# Altium schematic sheet LIDO-HW.100.RearConnector.SchDoc(LIDO-HW.100.RearConnector)
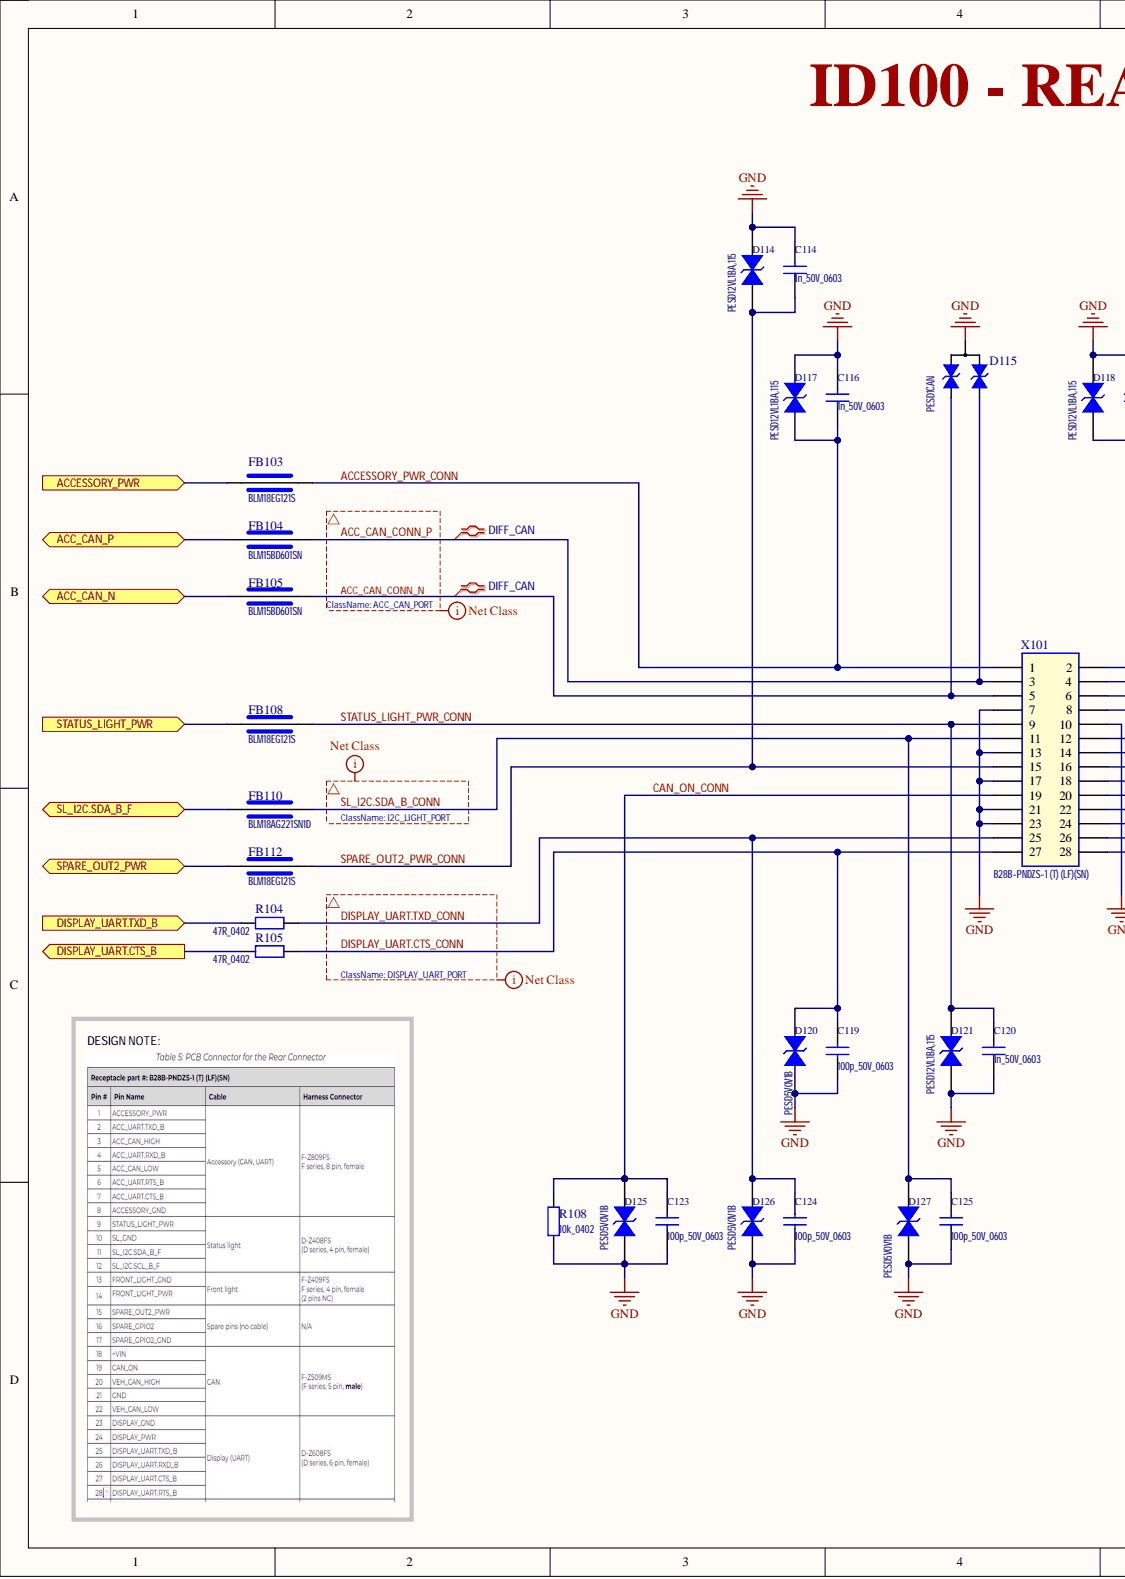
[diagram p0: LIDO-HW.100.RearConnector.SchDoc, left]
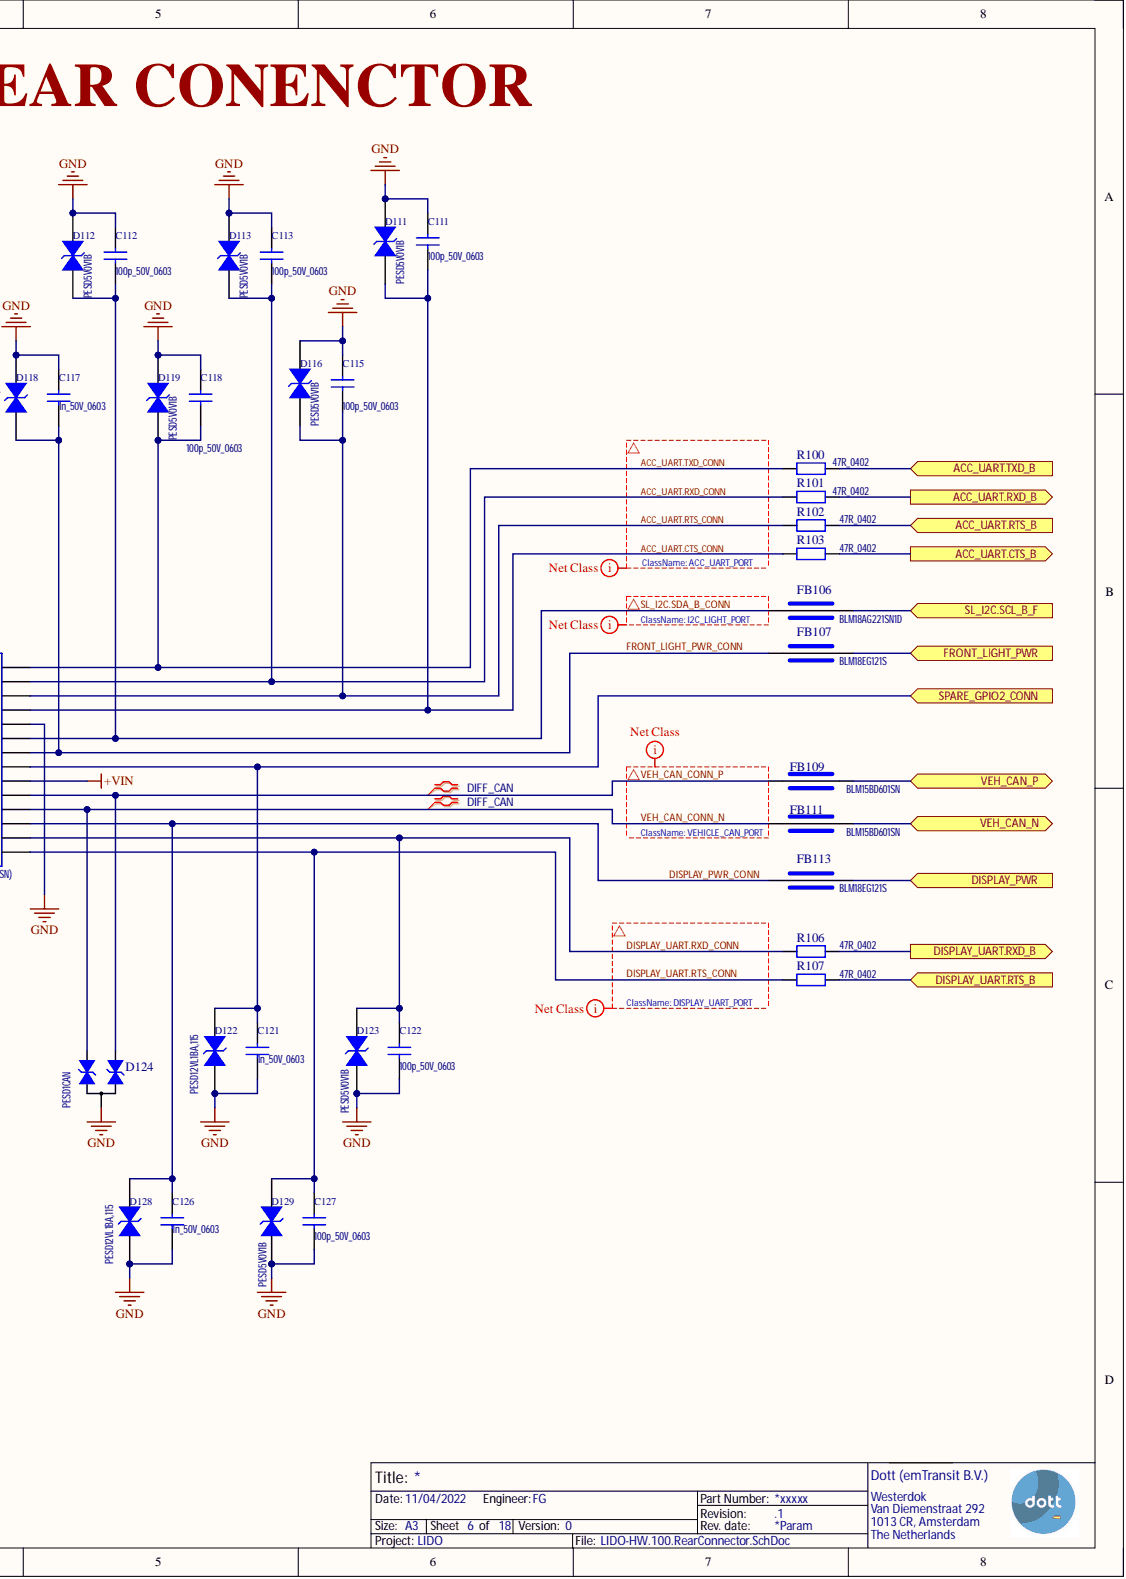
[diagram p1: LIDO-HW.100.RearConnector.SchDoc, right]

## Parts
C111  100p_50V_0603
C112  100p_50V_0603
C113  100p_50V_0603
C114  1n_50V_0603
C115  100p_50V_0603
C116  1n_50V_0603
C117  1n_50V_0603
C118  100p_50V_0603
C119  100p_50V_0603
C120  1n_50V_0603
C121  1n_50V_0603
C122  100p_50V_0603
C123  100p_50V_0603
C124  100p_50V_0603
C125  100p_50V_0603
C126  1n_50V_0603
C127  100p_50V_0603
D111  PESD5V0V1B
D112  PESD5V0V1B
D113  PESD5V0V1B
D114  PESD12VL1BA,115
D115  PESD1CAN
D116  PESD5V0V1B
D117  PESD12VL1BA,115
D118  PESD12VL1BA,115
D119  PESD5V0V1B
D120  PESD5V0V1B
D121  PESD12VL1BA,115
D122  PESD12VL1BA,115
D123  PESD5V0V1B
D124  PESD1CAN
D125  PESD5V0V1B
D126  PESD5V0V1B
D127  PESD5V0V1B
D128  PESD12VL1BA,115
D129  PESD5V0V1B
FB103  BLM18EG121S
FB104  BLM15BD601SN
FB105  BLM15BD601SN
FB106  BLM18AG221SN1D
FB107  BLM18EG121S
FB108  BLM18EG121S
FB109  BLM15BD601SN
FB110  BLM18AG221SN1D
FB111  BLM15BD601SN
FB112  BLM18EG121S
FB113  BLM18EG121S
R100  47R_0402
R101  47R_0402
R102  47R_0402
R103  47R_0402
R104  47R_0402
R105  47R_0402
R106  47R_0402
R107  47R_0402
R108  10k_0402
X101  B28B-PNDZS-1 (T) (LF)(SN)

## Ports
ACC_CAN_N, ACC_CAN_P, ACC_UART.CTS_B, ACC_UART.RTS_B, ACC_UART.RXD_B, ACC_UART.TXD_B, ACCESSORY_PWR, DISPLAY_PWR, DISPLAY_UART.CTS_B, DISPLAY_UART.RTS_B, DISPLAY_UART.RXD_B, DISPLAY_UART.TXD_B, FRONT_LIGHT_PWR, SL_I2C.SCL_B_F, SL_I2C.SDA_B_F, SPARE_GPIO2_CONN, SPARE_OUT2_PWR, STATUS_LIGHT_PWR, VEH_CAN_N, VEH_CAN_P

## Nets
+VIN: X101.18
GND: C111.1 C112.1 C113.1 C114.2 C115.1 C116.2 C117.2 C118.1 C119.1 C120.1 C121.1 C122.1 C123.1 C124.1 C125.1 C126.1 C127.1 D111.A D112.A D113.A D114.C D115.3 D116.A D117.C D118.C D119.A D120.A D121.A D122.A D123.A D124.3 D125.A D126.A D127.A D128.A D129.A R108.1 X101.7 X101.10 X101.13 X101.17 X101.21 X101.23
ACC_CAN_CONN_N: D115.2 FB105.2 X101.5
ACC_CAN_CONN_P: D115.1 FB104.2 X101.3
ACC_CAN_N: FB105.1 ; port ACC_CAN_N
ACC_CAN_P: FB104.1 ; port ACC_CAN_P
ACC_UART.CTS_B: R103.2 ; port ACC_UART.CTS_B
ACC_UART.CTS_CONN: C111.2 D111.C R103.1 X101.8
ACC_UART.RTS_B: R102.2 ; port ACC_UART.RTS_B
ACC_UART.RTS_CONN: C115.2 D116.C R102.1 X101.6
ACC_UART.RXD_B: R101.2 ; port ACC_UART.RXD_B
ACC_UART.RXD_CONN: C113.2 D113.C R101.1 X101.4
ACC_UART.TXD_B: R100.2 ; port ACC_UART.TXD_B
ACC_UART.TXD_CONN: C118.2 D119.C R100.1 X101.2
ACCESSORY_PWR: FB103.1 ; port ACCESSORY_PWR
ACCESSORY_PWR_CONN: C116.1 D117.A FB103.2 X101.1
CAN_ON_CONN: C123.2 D125.C R108.2 X101.19
DISPLAY_PWR: FB113.1 ; port DISPLAY_PWR
DISPLAY_PWR_CONN: C126.2 D128.C FB113.2 X101.24
DISPLAY_UART.CTS_B: R105.2 ; port DISPLAY_UART.CTS_B
DISPLAY_UART.CTS_CONN: C119.2 D120.C R105.1 X101.27
DISPLAY_UART.RTS_B: R107.2 ; port DISPLAY_UART.RTS_B
DISPLAY_UART.RTS_CONN: C127.2 D129.C R107.1 X101.28
DISPLAY_UART.RXD_B: R106.2 ; port DISPLAY_UART.RXD_B
DISPLAY_UART.RXD_CONN: C122.2 D123.C R106.1 X101.26
DISPLAY_UART.TXD_B: R104.2 ; port DISPLAY_UART.TXD_B
DISPLAY_UART.TXD_CONN: C124.2 D126.C R104.1 X101.25
FRONT_LIGHT_PWR: FB107.1 ; port FRONT_LIGHT_PWR
FRONT_LIGHT_PWR_CONN: C117.1 D118.A FB107.2 X101.14
SL_I2C.SCL_B_F: FB106.1 ; port SL_I2C.SCL_B_F
SL_I2C.SDA_B_CONN: C112.2 C125.2 D112.C D127.C FB106.2 FB110.2 X101.11 X101.12
SL_I2C.SDA_B_F: FB110.1 ; port SL_I2C.SDA_B_F
SPARE_GPIO2_CONN: C121.2 D122.C X101.16 ; port SPARE_GPIO2_CONN
SPARE_OUT2_PWR: FB112.1 ; port SPARE_OUT2_PWR
SPARE_OUT2_PWR_CONN: C114.1 D114.A FB112.2 X101.15
STATUS_LIGHT_PWR: FB108.1 ; port STATUS_LIGHT_PWR
STATUS_LIGHT_PWR_CONN: C120.2 D121.C FB108.2 X101.9
VEH_CAN_CONN_N: D124.2 FB111.2 X101.22
VEH_CAN_CONN_P: D124.1 FB109.2 X101.20
VEH_CAN_N: FB111.1 ; port VEH_CAN_N
VEH_CAN_P: FB109.1 ; port VEH_CAN_P

## Library data of the parts
C111-C127 = Ceramic: Ceramic CAP Symbol; Component Kind: Standard; Library Name: 01_Capacitors.SchLib
D111-D114 D116-D123 D125-D129 = BI-TVS_DIODE_B: Bidirectional TVS Diode Symbol; Component Kind: Standard; Library Name: 04_Circuit_Protection.SchLib
D115 D124 = Double Bi-TVS_B: Double bidirectional ESD protection diodes; Component Kind: Standard; Library Name: 04_Circuit_Protection.SchLib
FB103-FB113 = FERRITE_BEAD: Ferrite Bead Symbol; Component Kind: Standard; Library Name: 08_Filters.SchLib
R100-R108 = Resistor: Resistor Symbol; Component Kind: Standard; Library Name: 02_Resistors.SchLib
X101 = RECT_28PIN_2R_A: Rectengular connector, 24 pins, 2 row, Version A; Component Kind: Standard; Library Name: 20_Connectors_Rectangular.SchLib
